# Altium schematic sheet FGN410_PSU_IO.SchDoc
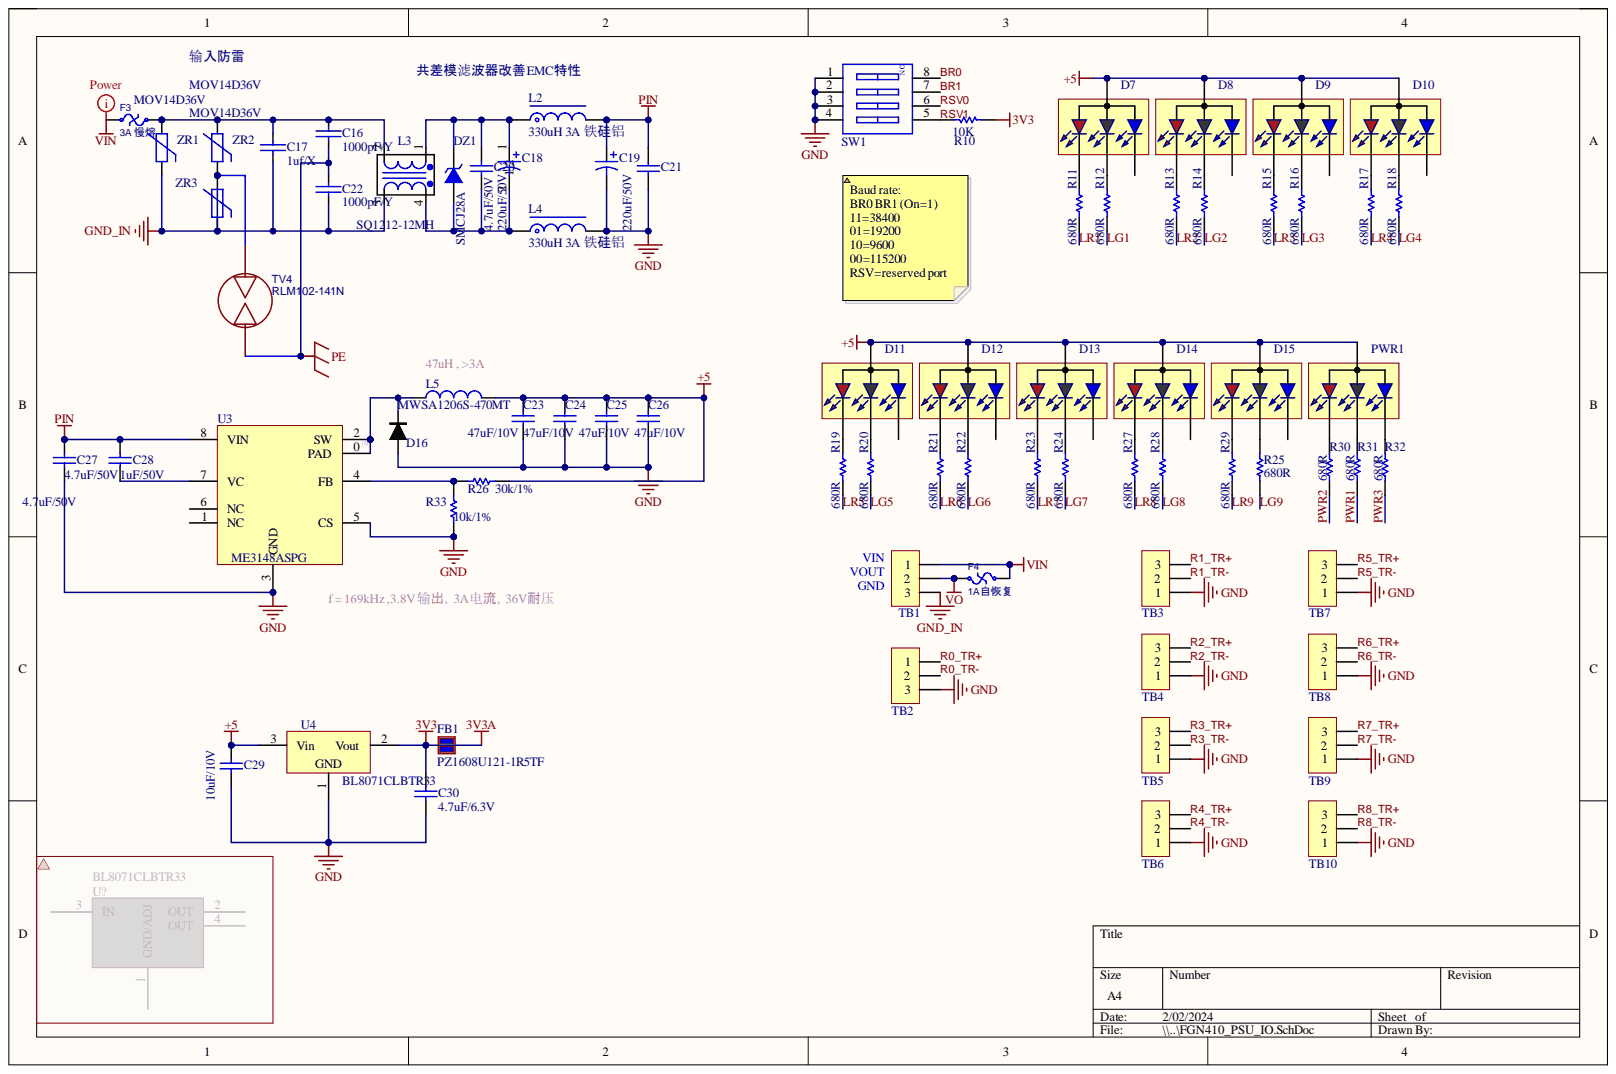
[diagram p0: FGN410_PSU_IO.SchDoc]

## Parts
C16  1000pF/Y  [C3216-1206]
C17  1uf/X  [C3216-1206]
C18  220uF/50V  [C10x16]
C19  220uF/50V  [C10x16]
C20  4.7uF/50V  [0805_C]
C21  4.7uF/50V  [0805_C]  (value not drawn)
C22  1000pF/Y  [C3216-1206]
C23  47uF/10V  [0805_C]
C24  47uF/10V  [0805_C]
C25  47uF/10V  [0805_C]
C26  47uF/10V  [0805_C]
C27  4.7uF/50V  [0805_C]
C28  1uF/50V  [0603_C]
C29  10uF/10V  [0603_C]
C30  4.7uF/6.3V  [0603_C]
D7  LED_RGB  [0603-Daul-LED]  (value not drawn)
D8  LED_RGB  [0603-Daul-LED]  (value not drawn)
D9  LED_RGB  [0603-Daul-LED]  (value not drawn)
D10  LED_RGB  [0603-Daul-LED]  (value not drawn)
D11  LED_RGB  [0603-Daul-LED]  (value not drawn)
D12  LED_RGB  [0603-Daul-LED]  (value not drawn)
D13  LED_RGB  [0603-Daul-LED]  (value not drawn)
D14  LED_RGB  [0603-Daul-LED]  (value not drawn)
D15  LED_RGB  [0603-Daul-LED]  (value not drawn)
D16  SS54  [DO-214AA(SMB)]  (value not drawn)
DZ1  SMCJ28A  [DO-214AB(SMC)]
F3  3A 慢熔  [1812]
F4  1A自恢复  [1812]
FB1  PZ1608U121-1R5TF  [0603FB]
L2  330uH 3A 铁硅铝  [50125A (13mm)]
L3  SQ1212-12MH  [SQ1212]
L4  330uH 3A 铁硅铝  [50125A (13mm)]
L5  MWSA1206S-470MT  [INDUCTOR-10/10]
PWR1  LED_RGB  [0603-Daul-LED]  (value not drawn)
R10  10K  [0603_R]
R11  680R  [0603_R]
R12  680R  [0603_R]
R13  680R  [0603_R]
R14  680R  [0603_R]
R15  680R  [0603_R]
R16  680R  [0603_R]
R17  680R  [0603_R]
R18  680R  [0603_R]
R19  680R  [0603_R]
R20  680R  [0603_R]
R21  680R  [0603_R]
R22  680R  [0603_R]
R23  680R  [0603_R]
R24  680R  [0603_R]
R25  680R  [0603_R]
R26  30k/1%  [0603_R]
R27  680R  [0603_R]
R28  680R  [0603_R]
R29  680R  [0603_R]
R30  680R  [0603_R]
R31  680R  [0603_R]
R32  680R  [0603_R]
R33  10k/1%  [0603_R]
SW1  BK1D-H-4P  [DIPSwitch]  (value not drawn)
TB1  HDR-1X3  [DIP-3P-5MM]  (value not drawn)
TB2  HDR-1X3  [DIP-3P-5MM]  (value not drawn)
TB3  HDR-1X3  [DIP-3P-5MM]  (value not drawn)
TB4  HDR-1X3  [DIP-3P-5MM]  (value not drawn)
TB5  HDR-1X3  [DIP-3P-5MM]  (value not drawn)
TB6  HDR-1X3  [DIP-3P-5MM]  (value not drawn)
TB7  HDR-1X3  [DIP-3P-5MM]  (value not drawn)
TB8  HDR-1X3  [DIP-3P-5MM]  (value not drawn)
TB9  HDR-1X3  [DIP-3P-5MM]  (value not drawn)
TB10  HDR-1X3  [DIP-3P-5MM]  (value not drawn)
TV4  RLM102-141N  [DIO-DT-SMD_L5.0-W2.8]
U3  ME3148ASPG  [SOIC-8_EP_150mil]
U4  BL8071CLBTR33  [SOT-223]
ZR1  MOV14D36V  [VDR 9D-4.5]
ZR2  MOV14D36V  [VDR 9D-4.5]
ZR3  MOV14D36V  [VDR 9D-4.5]

## Nets
3V3: C30.2 FB1.1 R10.1 U4.2
3V3A: FB1.2
+5: C23.2 C24.2 C25.1 C26.1 C29.1 D7.1 D8.1 D9.1 D10.1 D11.1 D12.1 D13.1 D14.1 D15.1 L5.2 PWR1.1 R26.2 U4.3
GND: C19.2 C21.1 C23.1 C24.1 C25.2 C26.2 C27.2 C29.2 C30.1 D16.2 L4.2 R33.2 SW1.1 SW1.2 SW1.3 SW1.4 TB2.3 TB3.1 TB4.1 TB5.1 TB6.1 TB7.1 TB8.1 TB9.1 TB10.1 U3.3(GND) U3.5(CS) U4.1
GND_IN: C17.2 C22.2 L3.3 TB1.3 ZR1.1 ZR3.1
PE: C16.2 C22.1 TV4.1
PIN: C19.1 C21.2 C27.1 C28.1 L2.2 U3.8(VIN)
VIN: F3.1 F4.1 TB1.1
VO: F4.2 TB1.2
BR0: SW1.8
BR1: SW1.7
LG1: R12.2
LG2: R14.2
LG3: R16.2
LG4: R18.2
LG5: R20.2
LG6: R22.2
LG7: R24.2
LG8: R28.2
LG9: R25.2
LR1: R11.2
LR2: R13.2
LR3: R15.2
LR4: R17.2
LR5: R19.2
LR6: R21.2
LR7: R23.2
LR8: R27.2
LR9: R29.2
PWR1: R31.2
PWR2: R30.2
PWR3: R32.2
R0_TR+: TB2.1
R0_TR-: TB2.2
R1_TR+: TB3.3
R1_TR-: TB3.2
R2_TR+: TB4.3
R2_TR-: TB4.2
R3_TR+: TB5.3
R3_TR-: TB5.2
R4_TR+: TB6.3
R4_TR-: TB6.2
R5_TR+: TB7.3
R5_TR-: TB7.2
R6_TR+: TB8.3
R6_TR-: TB8.2
R7_TR+: TB9.3
R7_TR-: TB9.2
R8_TR+: TB10.3
R8_TR-: TB10.2
RSV0: SW1.6
RSV1: R10.2 SW1.5
NetC16_1: C16.1 C17.1 F3.2 L3.2 ZR1.2 ZR2.2
NetC18_1: C18.1 C20.2 DZ1.1 L2.1 L3.1
NetC18_2: C18.2 C20.1 DZ1.2 L3.4 L4.1
NetC28_2: C28.2 U3.7(VC)
NetD7_2: D7.2 R11.1
NetD7_4: D7.4 R12.1
NetD8_2: D8.2 R13.1
NetD8_4: D8.4 R14.1
NetD9_2: D9.2 R15.1
NetD9_4: D9.4 R16.1
NetD10_2: D10.2 R17.1
NetD10_4: D10.4 R18.1
NetD11_2: D11.2 R19.1
NetD11_4: D11.4 R20.1
NetD12_2: D12.2 R21.1
NetD12_4: D12.4 R22.1
NetD13_2: D13.2 R23.1
NetD13_4: D13.4 R24.1
NetD14_2: D14.2 R27.1
NetD14_4: D14.4 R28.1
NetD15_2: D15.2 R29.1
NetD15_4: D15.4 R25.1
NetD16_1: D16.1 L5.1 U3.2(SW) U3.9(PAD)
NetPWR1_2: PWR1.2 R30.1
NetPWR1_3: PWR1.3 R32.1
NetPWR1_4: PWR1.4 R31.1
NetR26_1: R26.1 R33.1 U3.4(FB)
NetTV4_2: TV4.2 ZR2.1 ZR3.2

Not connected: D7 3; D8 3; D9 3; D10 3; D11 3; D12 3; D13 3; D14 3; D15 3; U3 1(NC) 6(NC)

## Library data of the parts
C16 C22 = CAP_1: 1000pF/Y 1206; X7R: 100nF/16V; Component Kind: Standard; Library Name: My_Int_Library.IntLib
C17 = CAP_1: 1uf/X 1206; X7R: 100nF/16V; Component Kind: Standard; Library Name: My_Int_Library.IntLib
C18 C19 = Cap Pol1: Polarized Capacitor (Radial); Component Kind: Standard; LatestRevisionDate: 17-Jul-2002; LatestRevisionNote: Re-released for DXP Platform.; Library Name: Miscellaneous Devices.IntLib; PackageDescription: Polarized Capacitor (Radial); 2 Leads; PackageReference: RB7.6-15; Published: 8-Jun-2000; Signal Integrity: Cap Pol; Simulation: CAP; Value: 220uF/50V; Publisher: Altium Limited
C20 C21 C23-C26 C28 C30 = Cap: Capacitor; Precision: ±10%; Voltage: 50V; Component Kind: Standard; Library Name: Miscellaneous Devices.IntLib
C27 = Cap: Capacitor; Precision: ±10%; Voltage: 35V; Component Kind: Standard; Library Name: Miscellaneous Devices.IntLib
C29 = Cap_3: CAP, CERM, 2000V, +/- 20%, X7S; AEC Category: Q200; Tolerance_%: 20; Component Kind: Standard; MountType: SMT; TempCo: X7S; Library Name: ICI.SchLib
D16 = DIODE_1: Component Kind: Standard; Description: SS54; Library Name: My_Int_Library.IntLib
DZ1 = Diode Zener_1: Component Kind: Standard; Description: SMCJ28A; LatestRevisionDate: 17-Jul-2002; LatestRevisionNote: Re-released for DXP Platform.; Library Name: My_Int_Library.IntLib; Published: 8-Jun-2000; Publisher: Altium Limited
F3 F4 = Fuse Thermal: Thermal Fuse; Component Kind: Standard; LatestRevisionDate: 17-Jul-2002; LatestRevisionNote: Re-released for DXP Platform.; PackageReference: PIN-W2/E2.8; Published: 24-Mar-1999; Library Name: zzt.SchLib; Publisher: Altium Limited
FB1 = FB_2: Component Kind: Standard; Library Name: My_Int_Library.IntLib
L2 L4 = L-CD: 330uH 3A 铁硅铝  50125A; Component Kind: Standard; Library Name: My_Int_Library.IntLib
L3 = 10mH/4A: https://item.taobao.com/item.htm?spm=a1z10.5-c-s.w4002-23071751207.13.48933dc6kNAH0S&id=18848328930; Manufacturer: Generic Components; Class: Passive; SubClass: Transformer; Component Kind: Standard; Library Name: FGM310.SCHLIB; Published: 08/06/2000; Publisher: Altium Hobart Technology Centre
L5 = Inductor: Code_IEC: 1005; Code_JEITA: 0402; Component Kind: Standard; Description: Inductor; LatestRevisionDate: 29-May-2009; LatestRevisionNote: IPC-7351 Footprint Added.; Library Name: Miscellaneous Devices.IntLib; PackageDescription: Chip Inductor; PackageReference: 0402-A; Published: 8-Jun-2000; Publisher: Altium Limited
R10-R33 = Res1: Resistor; Power: 1/4W; Precision: ±1%; Component Kind: Standard; Library Name: Miscellaneous Devices.IntLib
  R10: Value: 10K
  R11-R25 R27-R32: Value: 680R
  R26: Value: 30k/1%
  R33: Value: 10k/1%
SW1 = 编码开关4: Component Kind: Standard; Library Name: My_Int_Library.IntLib
TB1-TB10 = Header 3: 3P接插件; 版权归属: 源创客; BOM名称: 3P接插件; Component Kind: Standard; Library Name: 接插件-脚距2.54.SchLib; 学习资源: www.ooocke.com
TV4 = 4532-091-LF: BOM_Manufacturer: Brightking; BOM_JLCPCB Part Class: Extended Part; BOM_Manufacturer Part: 4532-091-LF; BOM_Supplier: LCSC; BOM_Supplier Part: C78323; Component Kind: Standard; spicePre: T; spiceSymbolName: 4532-091-LF
U4 = ASM1117-3.3: 800mA and 1A Low Dropout Positive Regulator 2.85V, 3.3V, 5V, and Adjustable; Component Kind: Standard; Library Name: My_Int_Library.IntLib
ZR1-ZR3 = R_VDR: 压敏电阻; 版权归属: 源创客; BOM名称: 压敏电阻; Component Kind: Standard; Library Name: 电阻-电容-电感.SchLib; 学习资源: www.ooocke.com
D7-D15 PWR1 = LED_RGB: Component Kind: Standard; Description: LED_RGB; Library Name: zzt.SchLib
U3 = ME3148ASPG: Component Kind: Standard; Library Name: FGM310_V15.IntLib
